# Altium schematic sheet TOP.SchDoc(TOP)
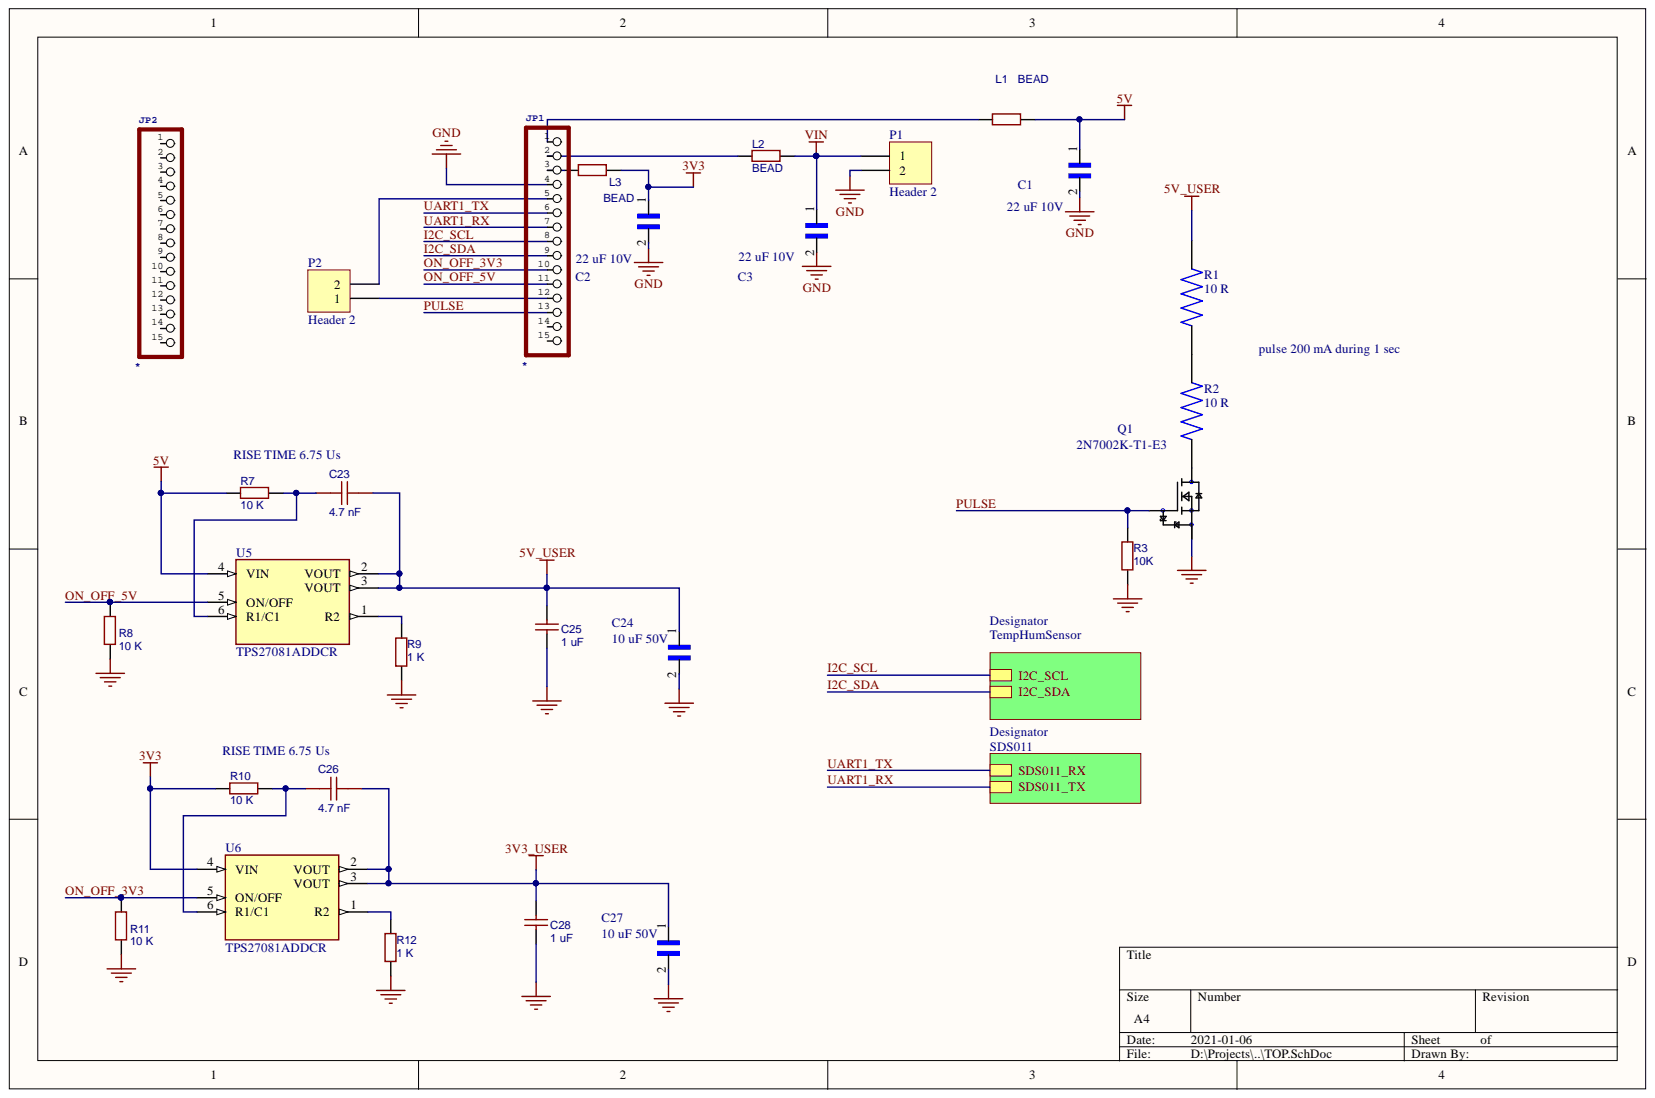
[diagram p0: TOP.SchDoc]

## Parts
C1  22 uF 10V  [*ANTC3225X280N]
C2  22 uF 10V  [*ANTC3225X280N]
C3  22 uF 10V  [*ANTC3225X280N]
C23  4.7 nF  [0603_1608METRIC_PAD1.05X0.95MM_HANDSOLDER]
C24  10 uF 50V  [*ANTC3225X280N]
C25  1 uF  [0603_1608METRIC_PAD1.05X0.95MM_HANDSOLDER]
C26  4.7 nF  [0603_1608METRIC_PAD1.05X0.95MM_HANDSOLDER]
C27  10 uF 50V  [*ANTC3225X280N]
C28  1 uF  [0603_1608METRIC_PAD1.05X0.95MM_HANDSOLDER]
JP1  PINHD-1X15-CASTELLATED  [1X15-CASTELL]  (value not drawn)
JP2  PINHD-1X15-CASTELLATED  [1X15-CASTELL]  (value not drawn)
L1  BEAD  [0603_1608METRIC_PAD1.05X0.95MM_HANDSOLDER]
L2  BEAD  [0603_1608METRIC_PAD1.05X0.95MM_HANDSOLDER]
L3  BEAD  [0603_1608METRIC_PAD1.05X0.95MM_HANDSOLDER]
P1  Header 2  [HDR1X2]
P2  Header 2  [HDR1X2]
Q1  2N7002K-T1-E3  [*SOT23]
R1  Comment_1: 10 R  [RESC6332X120N]  (hidden comment: 1-2176230-5)
R2  Comment_1: 10 R  [RESC6332X120N]  (hidden comment: 1-2176230-5)
R3  10K  [0603_1608METRIC_PAD1.05X0.95MM_HANDSOLDER]
R7  10 K  [0603_1608METRIC_PAD1.05X0.95MM_HANDSOLDER]
R8  10 K  [0603_1608METRIC_PAD1.05X0.95MM_HANDSOLDER]
R9  1 K  [0603_1608METRIC_PAD1.05X0.95MM_HANDSOLDER]
R10  10 K  [0603_1608METRIC_PAD1.05X0.95MM_HANDSOLDER]
R11  10 K  [0603_1608METRIC_PAD1.05X0.95MM_HANDSOLDER]
R12  1 K  [0603_1608METRIC_PAD1.05X0.95MM_HANDSOLDER]
U5  TPS27081ADDCR  [SOT95P280X110-6N]
U6  TPS27081ADDCR  [SOT95P280X110-6N]

## Nets
3V3: C2.1 L3.2 R10.2 U6.4(VIN)
3V3_USER: C26.1 C27.1 C28.2 U6.2(VOUT) U6.3(VOUT)
5V: C1.1 L1.2 R7.2 U5.4(VIN)
5V_USER: C23.1 C24.1 C25.2 R1.2 U5.2(VOUT) U5.3(VOUT)
GND: C1.2 C2.2 C3.2 C24.2 C25.1 C27.2 C28.1 JP1.4 P1.2 Q1.2 R3.2 R8.1 R9.2 R11.1 R12.2
VIN: C3.1 L2.2 P1.1
I2C_SCL: JP1.8
I2C_SDA: JP1.9
ON_OFF_3V3: JP1.10 R11.2 U6.5(ON/OFF)
ON_OFF_5V: JP1.11 R8.2 U5.5(ON/OFF)
PULSE: JP1.13 Q1.1 R3.1
UART1_RX: JP1.7
UART1_TX: JP1.6
NetC23_2: C23.2 R7.1 U5.6(R1/C1)
NetC26_2: C26.2 R10.1 U6.6(R1/C1)
NetJP1_1: JP1.1 L1.1
NetJP1_2: JP1.2 L2.1
NetJP1_3: JP1.3 L3.1
NetJP1_5: JP1.5 P2.2
NetJP1_12: JP1.12 P2.1
NetJP1_14: JP1.14
NetJP1_15: JP1.15
NetJP2_1: JP2.1
NetJP2_2: JP2.2
NetJP2_3: JP2.3
NetJP2_4: JP2.4
NetJP2_5: JP2.5
NetJP2_6: JP2.6
NetJP2_7: JP2.7
NetJP2_8: JP2.8
NetJP2_9: JP2.9
NetJP2_10: JP2.10
NetJP2_11: JP2.11
NetJP2_12: JP2.12
NetJP2_13: JP2.13
NetJP2_14: JP2.14
NetJP2_15: JP2.15
NetQ1_3: Q1.3 R2.1
NetR1_1: R1.1 R2.2
NetR9_1: R9.1 U5.1(R2)
NetR12_1: R12.1 U6.1(R2)

## Library data of the parts
C1-C3 C24 C27 = CL32B106KBJNNNE: SnapEDA_Link: https://www.snapeda.com/parts/CL32B106KBJNNNE/Samsung%20Electro-Mechanics/view-part/3598310/?ref=snap; Component Kind: Standard; Library Name: Relais.IntLib
C23 C26 = 100nF: BOM_Manufacturer Part: CL10B104KA8NNNC; BOM_Manufacturer: SAMSUNG; nameAlias: Capacitance; spiceSymbolName: CL10B104KA8NNNC; spicePre: C; Component Kind: Standard; BOM_Supplier Part: C1590; BOM_Supplier: LCSC
C25 C28 = 1uF: BOM_Manufacturer Part: CL10A105KO8NNNC; BOM_Manufacturer: SAMSUNG; nameAlias: Capacitance; spiceSymbolName: CL10A105KO8NNNC; spicePre: C; Component Kind: Standard; BOM_Supplier Part: C1592; BOM_Supplier: LCSC
JP1 JP2 = PINHD-1X15-CASTELLATED: PIN HEADER; SymbolName_1: PINHD15; GateName_1: A; LibraryName: Arduino-connectors; DeviceSetName: PINHD-1X15; DeviceName: -CASTELLATED; Component Kind: Standard; Library Name: NANO33IoTV2.0.IntLib
L1-L3 R3 R7-R12 = 10K: BOM_Manufacturer Part: RC0603JR-0710KL; BOM_Manufacturer: YAGEO; nameAlias: Resistance (Ohms); spiceSymbolName: RC0603JR-0710KL; spicePre: R; Component Kind: Standard; BOM_Supplier Part: C99198; BOM_Supplier: LCSC
P1 P2 = Header 2: Header, 2-Pin; Signal Integrity: Connector; LatestRevisionNote: Re-released for DXP Platform.; LatestRevisionDate: 17-Jul-2002; Component Kind: Standard; Publisher: Altium Limited; Library Name: Rhayo_DIY.IntLib
Q1 = 2N7002K-T1-E3: MOSFET, Power; N-Ch; VDSS 60V; RDS_ON_ 2 Ohms; ID 300mA; TO-236 _SOT-23_; PD 0.35W; MF: Vishay Siliconix; SnapEDA_Link: https://www.snapeda.com/parts/2N7002K-T1-E3/Vishay%20Siliconix/view-part/?ref=snap; Price: None; Package: SOT-23 Vishay; MP: 2N7002K-T1-E3; Library Name: 2N7002K-T1-E3.IntLib; Component Kind: Standard; Availability: Unavailable
R1 R2 = 352212RJT: Thick Film Resistors - SMD 3522 12R 5% 3W; Resistance: 12; MF: TE Connectivity; Tolerance: 5%; SnapEDA_Link: https://www.snapeda.com/parts/352212RJT/TE%20Connectivity%20Passive%20Product/view-part/1008159/?ref=snap; Price: None; Power_Rating: 3 W; Package: 2512; MP: 352212RJT; Mouser-Purchase-URL: https://snapeda.com/shop?store=Mouser&id=1008159; Component Kind: Standard; Availability: Unavailable; Library Name: Relais.IntLib
U5 U6 = TPS27081ADDCR: Power Switch/Driver 1:1 3A TSOT-23-6; MF: Texas Instruments; SnapEDA_Link: https://www.snapeda.com/parts/TPS27081ADDCR/Texas%20Instruments/view-part/426704/?ref=snap; Price: None; Package: SOT-23-6 Texas Instruments; MP: TPS27081ADDCR; Library Name: TPS27081ADDCR.IntLib; Component Kind: Standard; Availability: Unavailable
